# Altium schematic sheet Phare.SchDoc
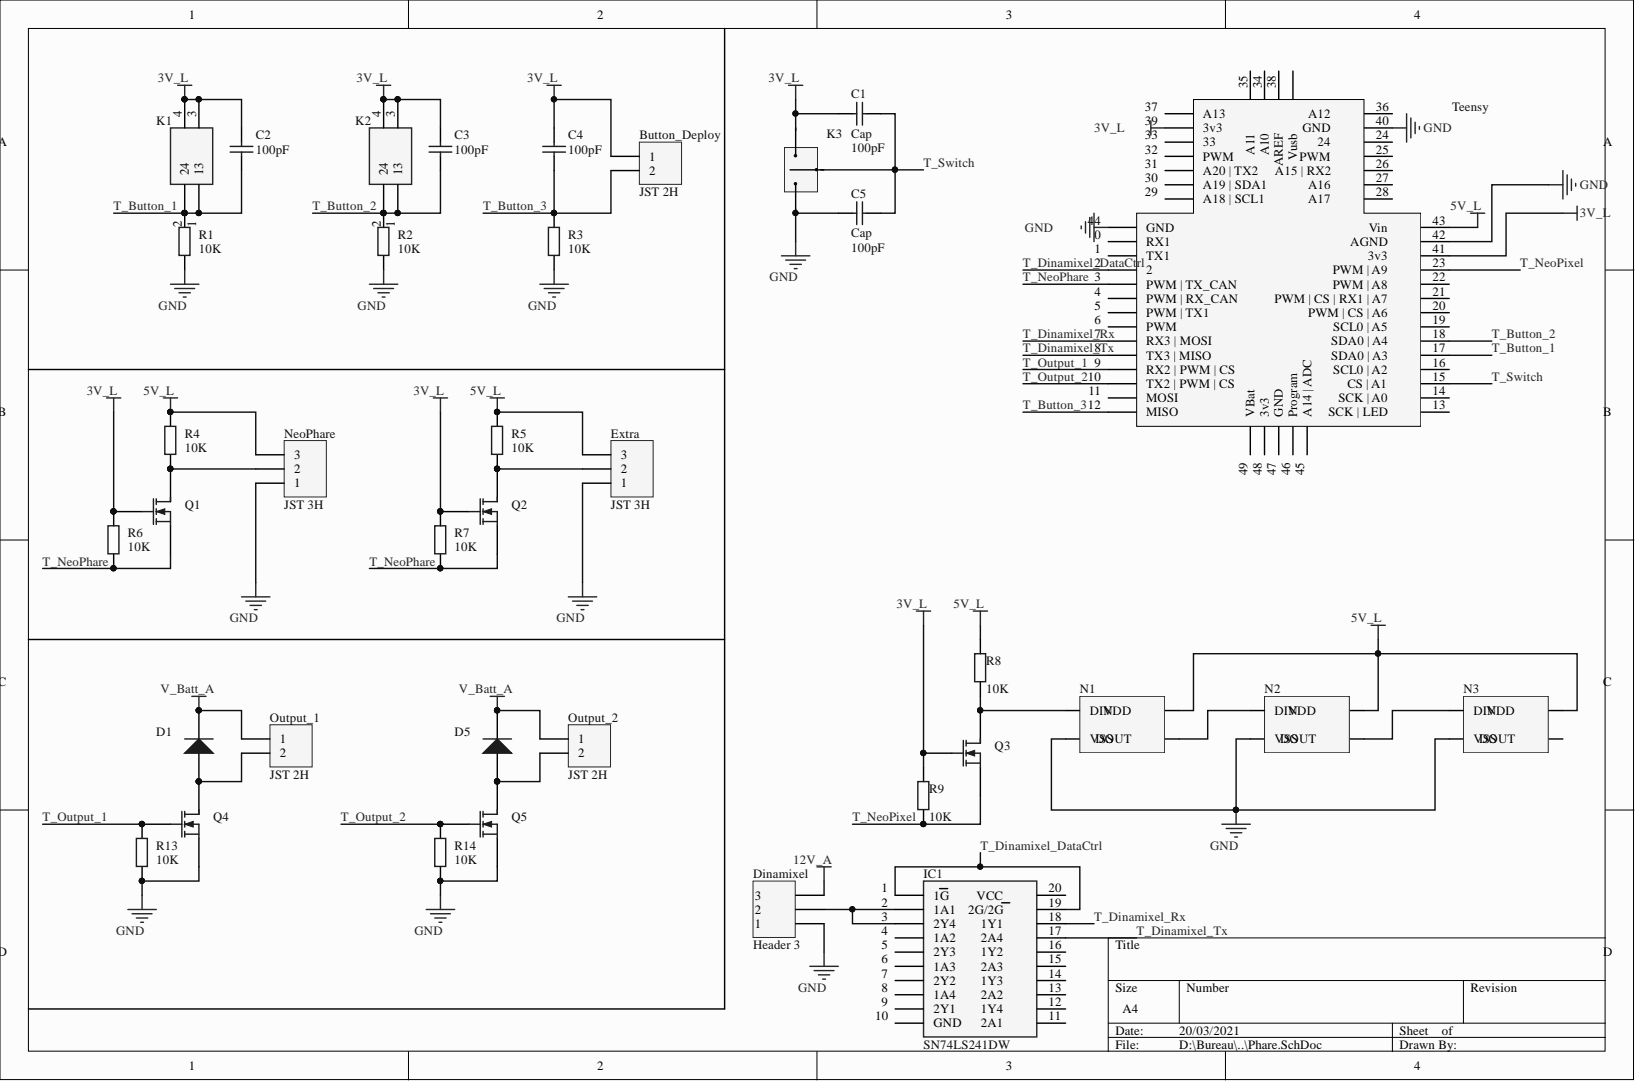
[diagram p0: Phare.SchDoc]

## Parts
Button_Deploy  JST 2H  [S2B-XH-A]
C1  Cap  value 100pF  [Capacitor 1206]
C2  value 100pF  [Capacitor 1206]  (hidden comment: Cap)
C3  value 100pF  [Capacitor 1206]  (hidden comment: Cap)
C4  value 100pF  [Capacitor 1206]  (hidden comment: Cap)
C5  Cap  value 100pF  [Capacitor 1206]
D1  Diode  [SMC]  (value not drawn)
D5  Diode  [SMC]  (value not drawn)
Dinamixel  Header 3  [Molex SPOX 3x1H]
Extra  JST 3H  [S3B-XH-A]
IC1  SN74LS241DW  [SOIC127P1030X265-20N]
K1  4-1437565-1  [FSM-SWITCH]  (value not drawn)
K2  4-1437565-1  [FSM-SWITCH]  (value not drawn)
K3  Switch  [SWITCH]  (value not drawn)
N1  NeoPixel  [Neopixel]
N2  NeoPixel  [Neopixel]
N3  NeoPixel  [Neopixel]
NeoPhare  JST 3H  [S3B-XH-A]
Output_1  JST 2H  [S2B-XH-A]
Output_2  JST 2H  [S2B-XH-A]
Q1  MOSFET-N  [SOT23_M]  (value not drawn)
Q2  MOSFET-N  [SOT23_M]  (value not drawn)
Q3  MOSFET-N  [SOT23_M]  (value not drawn)
Q4  MOSFET-N  [SOT23_M]  (value not drawn)
Q5  MOSFET-N  [SOT23_M]  (value not drawn)
R1  value 10K  [Resistor 1206]  (hidden comment: Res2)
R2  value 10K  [Resistor 1206]  (hidden comment: Res2)
R3  value 10K  [Resistor 1206]  (hidden comment: Res2)
R4  value 10K  [Resistor 1206]  (hidden comment: Res2)
R5  value 10K  [Resistor 1206]  (hidden comment: Res2)
R6  value 10K  [Resistor 1206]  (hidden comment: Res2)
R7  value 10K  [Resistor 1206]  (hidden comment: Res2)
R8  value 10K  [Resistor 1206]  (hidden comment: Res2)
R9  value 10K  [Resistor 1206]  (hidden comment: Res2)
R13  value 10K  [Resistor 1206]  (hidden comment: Res2)
R14  value 10K  [Resistor 1206]  (hidden comment: Res2)
Teensy  Teensy 3.2  [teensy]  (value not drawn)

## Nets
3V_L: Button_Deploy.1 C1.1 C2.1 C3.1 C4.1 K1.3 K1.4 K2.3 K2.4 K3.0 Q1.2 Q2.2 Q3.2 R6.1 R7.1 R9.1 Teensy.39(3v3) Teensy.41
5V_L: Extra.3 N1.4 N2.4 N3.4 NeoPhare.3 R4.1 R5.1 R8.1 Teensy.43
12V_A: Dinamixel.3
GND: C5.1 Dinamixel.1 Extra.1 K3.2 N1.2(VSS) N2.2(VSS) N3.2(VSS) NeoPhare.1 Q4.3 Q5.3 R1.2 R2.2 R3.2 R13.2 R14.2 Teensy.40 Teensy.42 Teensy.44(GND)
V_BATT_A: D1.2 D5.2 Output_1.1 Output_2.1
T_BUTTON_1: C2.2 K1.1(1_3) K1.2(2_4) R1.1 Teensy.17
T_BUTTON_2: C3.2 K2.1(1_3) K2.2(2_4) R2.1 Teensy.18
T_BUTTON_3: Button_Deploy.2 C4.2 R3.1 Teensy.12(MISO)
T_DINAMIXEL_DATACTRL: IC1.1(1G) IC1.19 Teensy.2
T_DINAMIXEL_RX: IC1.18 Teensy.7(RX3_|_MOSI)
T_DINAMIXEL_TX: IC1.17 Teensy.8(TX3_|_MISO)
T_NEOPHARE: Q1.3 Q2.3 R6.2 R7.2 Teensy.3(PWM_|_TX_CAN)
T_NEOPIXEL: Q3.3 R9.2 Teensy.23
T_OUTPUT_1: Q4.2 R13.1 Teensy.9(RX2_|_PWM_|_CS)
T_OUTPUT_2: Q5.2 R14.1 Teensy.10(TX2_|_PWM_|_CS)
T_SWITCH: C1.2 C5.2 K3.1 Teensy.15
NetD1_1: D1.1 Output_1.2 Q4.1
NetD5_1: D5.1 Output_2.2 Q5.1
NetDinamixel_2: Dinamixel.2 IC1.2(1A1) IC1.3(2Y4)
NetExtra_2: Extra.2 Q2.1 R5.2
NetN1_1: N1.1 N2.3(DIN)
NetN1_3: N1.3(DIN) Q3.1 R8.2
NetN2_1: N2.1 N3.3(DIN)
NetNeoPhare_2: NeoPhare.2 Q1.1 R4.2

Not connected: IC1 4(1A2) 5(2Y3) 6(1A3) 7(2Y2) 8(1A4) 9(2Y1) 10(GND) 11 12 13 14 15 16 20; N3 1; Teensy 0(RX1) 1(TX1) 4(PWM_|_RX_CAN) 5(PWM_|_TX1) 6(PWM) 11(MOSI) 13 14 16 19 20 21 22 24 25 26 27 28 29(A18_|_SCL1) 30(A19_|_SDA1) 31(A20_|_TX2) 32(PWM) 33 34 35 36 37(A13) 38 45(A14_|_ADC) 46(Program) 47(GND) 48(3v3) 49(VBat) 50

## Library data of the parts
Button_Deploy Output_1 Output_2 = Header 2: Header, 2-Pin; Component Kind: Standard; LatestRevisionDate: 17-Jul-2002; LatestRevisionNote: Re-released for DXP Platform.; Library Name: Miscellaneous Connectors.IntLib; Signal Integrity: Connector; Publisher: Altium Limited
C1-C5 = Cap: Capacitor; Component Kind: Standard; LatestRevisionDate: 17-Jul-2002; LatestRevisionNote: Re-released for DXP Platform.; Library Name: Miscellaneous Devices.IntLib; PackageDescription: Radial Cap, Thru-Hole; 2 Leads; 0.3 in Pin Spacing; PackageReference: RAD-0.3; Published: 8-Jun-2000; Signal Integrity: Cap; Simulation: CAP; Publisher: Altium Limited
D1 D5 = Diode: Default Diode; Code_JEDEC: DO-214-AB; Component Kind: Standard; LatestRevisionDate: 17-Jul-2002; LatestRevisionNote: Re-released for DXP Platform.; Library Name: Miscellaneous Devices.IntLib; PackageDescription: DO-214-AB/SMC; 2 C-Bend Leads; Body 7.9 x 5.9 mm, inc. leads (LxW); PackageReference: SMC; PackageVersion: Sep-1996; Published: 8-Jun-2000; Signal Integrity: Diode; Simulation: DIODE; Publisher: Altium Limited
Dinamixel Extra NeoPhare = Header 3: Header, 3-Pin; Component Kind: Standard; LatestRevisionDate: 17-Jul-2002; LatestRevisionNote: Re-released for DXP Platform.; Library Name: Miscellaneous Connectors.IntLib; Signal Integrity: Connector; Publisher: Altium Limited
IC1 = SN74LS241DW: Integrated Circuit; Manufacturer_Name: Texas Instruments; Arrow Part Number: SN74LS241DW; Arrow Price/Stock: https://www.arrow.com/en/products/sn74ls241dw/texas-instruments; Component Kind: Standard; Datasheet Link: http://www.ti.com/lit/gpn/SN74LS241; Height: 2.65mm; Manufacturer_Part_Number: SN74LS241DW; Mouser Part Number: 595-SN74LS241DW; Mouser Price/Stock: https://www.mouser.co.uk/ProductDetail/Texas-Instruments/SN74LS241DW?qs=SL3LIuy2dWxYUNFhEYJjgw%3D%3D
K1 K2 = 4-1437565-1: Switch; Manufacturer_Name: TE Connectivity; Arrow Part Number: 4-1437565-1; Arrow Price/Stock: https://www.arrow.com/en/products/4-1437565-1/te-connectivity; Component Kind: Standard; Datasheet Link: http://docs-emea.rs-online.com/webdocs/14a3/0900766b814a3369.pdf; Manufacturer_Part_Number: 4-1437565-1; Mouser Part Number: N/A; Mouser Price/Stock: https://www.mouser.com/Search/Refine.aspx?Keyword=N%2FA
K3 = Switch: 3 pos switch, 09.03290.01; Component Kind: Standard; Library Name: Robot_2020.SchLib
Q1-Q5 = MOSFET-N: N-Channel MOSFET; Component Kind: Standard; LatestRevisionDate: 17-Jul-2002; LatestRevisionNote: Re-released for DXP Platform.; Library Name: Miscellaneous Devices.IntLib; PackageDescription: TO, Flat Index; 3 In-Line, Axial Leads; Body Dia. 4.6mm; Lead Dia. 0.5 mm (max); PackageReference: E3; PackageVersion: 1995/1996; Published: 8-Jun-2000; Simulation: NMOS; Publisher: Altium Limited
R1-R9 R13 R14 = Res2: Resistor; Component Kind: Standard; LatestRevisionDate: 17-Jul-2002; LatestRevisionNote: Re-released for DXP Platform.; Library Name: Miscellaneous Devices.IntLib; PackageDescription: Axial Device, Thru-Hole; 2 Leads; 0.4 in Pin Spacing; PackageReference: AXIAL-0.4; Published: 8-Jun-2000; Signal Integrity: Res; Simulation: RESISTOR; Publisher: Altium Limited
Teensy = teensy3.2: Component Kind: Standard; Description: Teensy 3.2; Library Name: Robot_2020.SchLib
N1-N3 = NeoPixel: Component Kind: Standard; Library Name: Robot_2020.SchLib
